# Altium schematic sheet ESP12_MinBreakOut.SchDoc
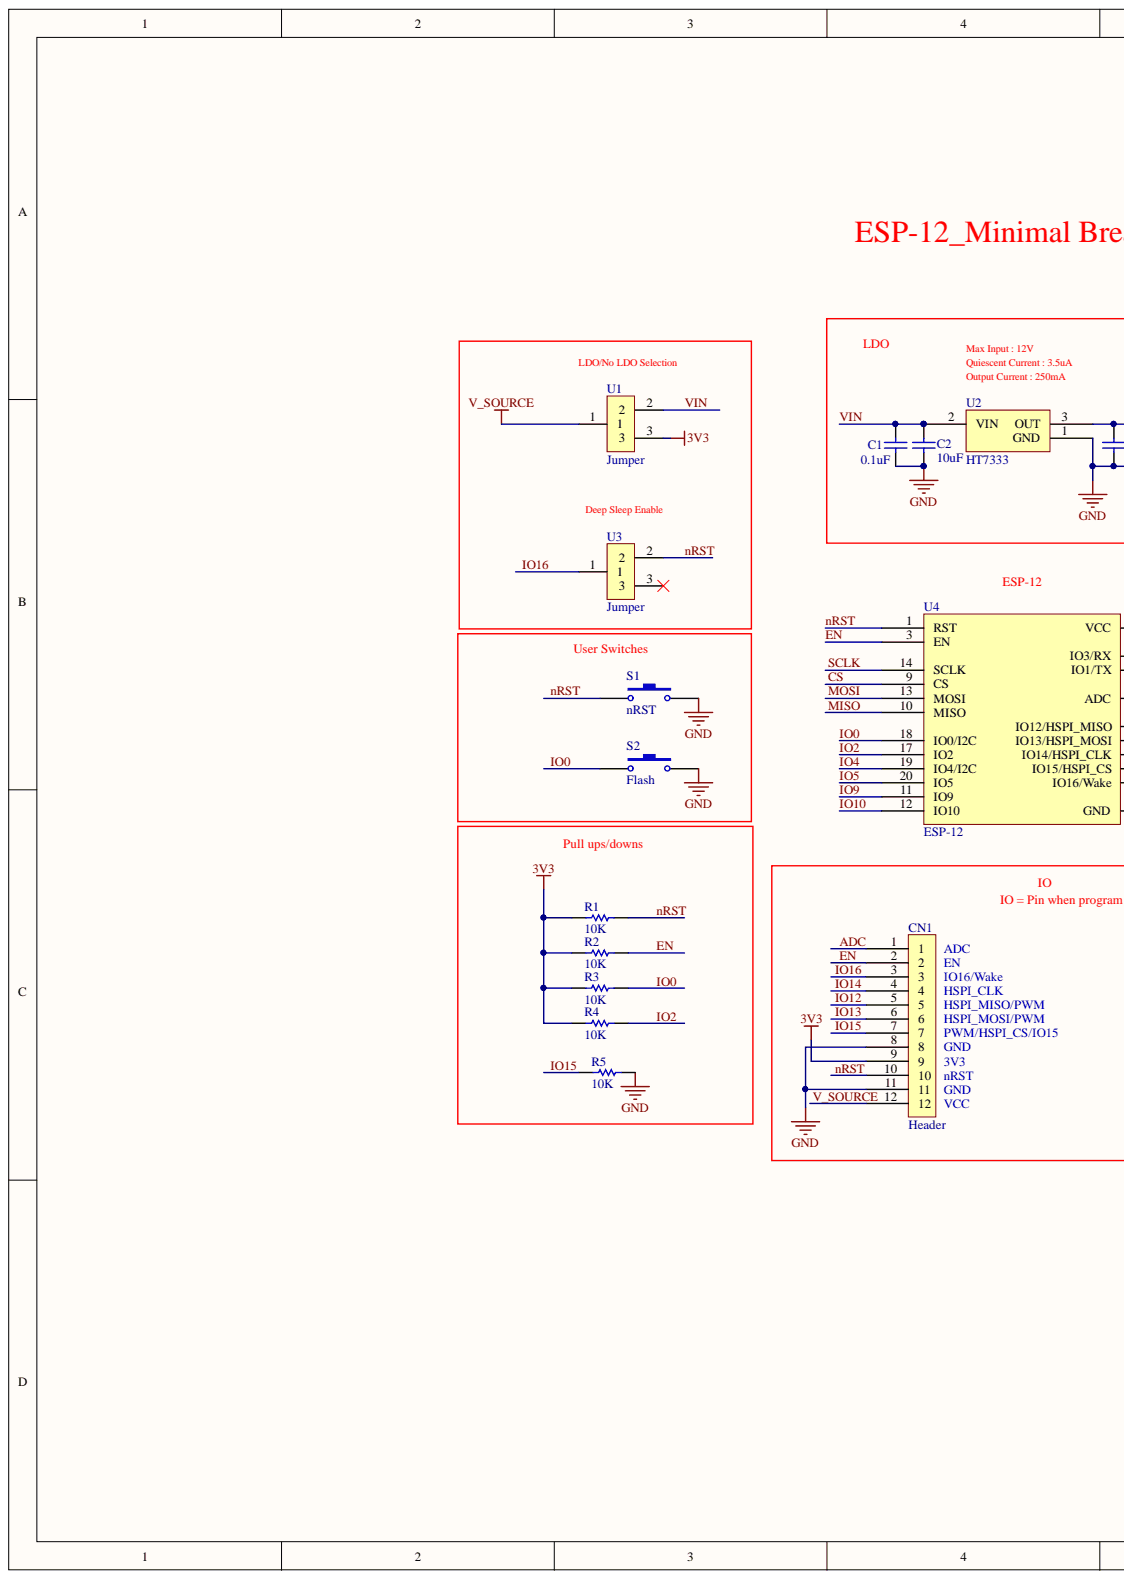
[diagram p0: ESP12_MinBreakOut.SchDoc, left]
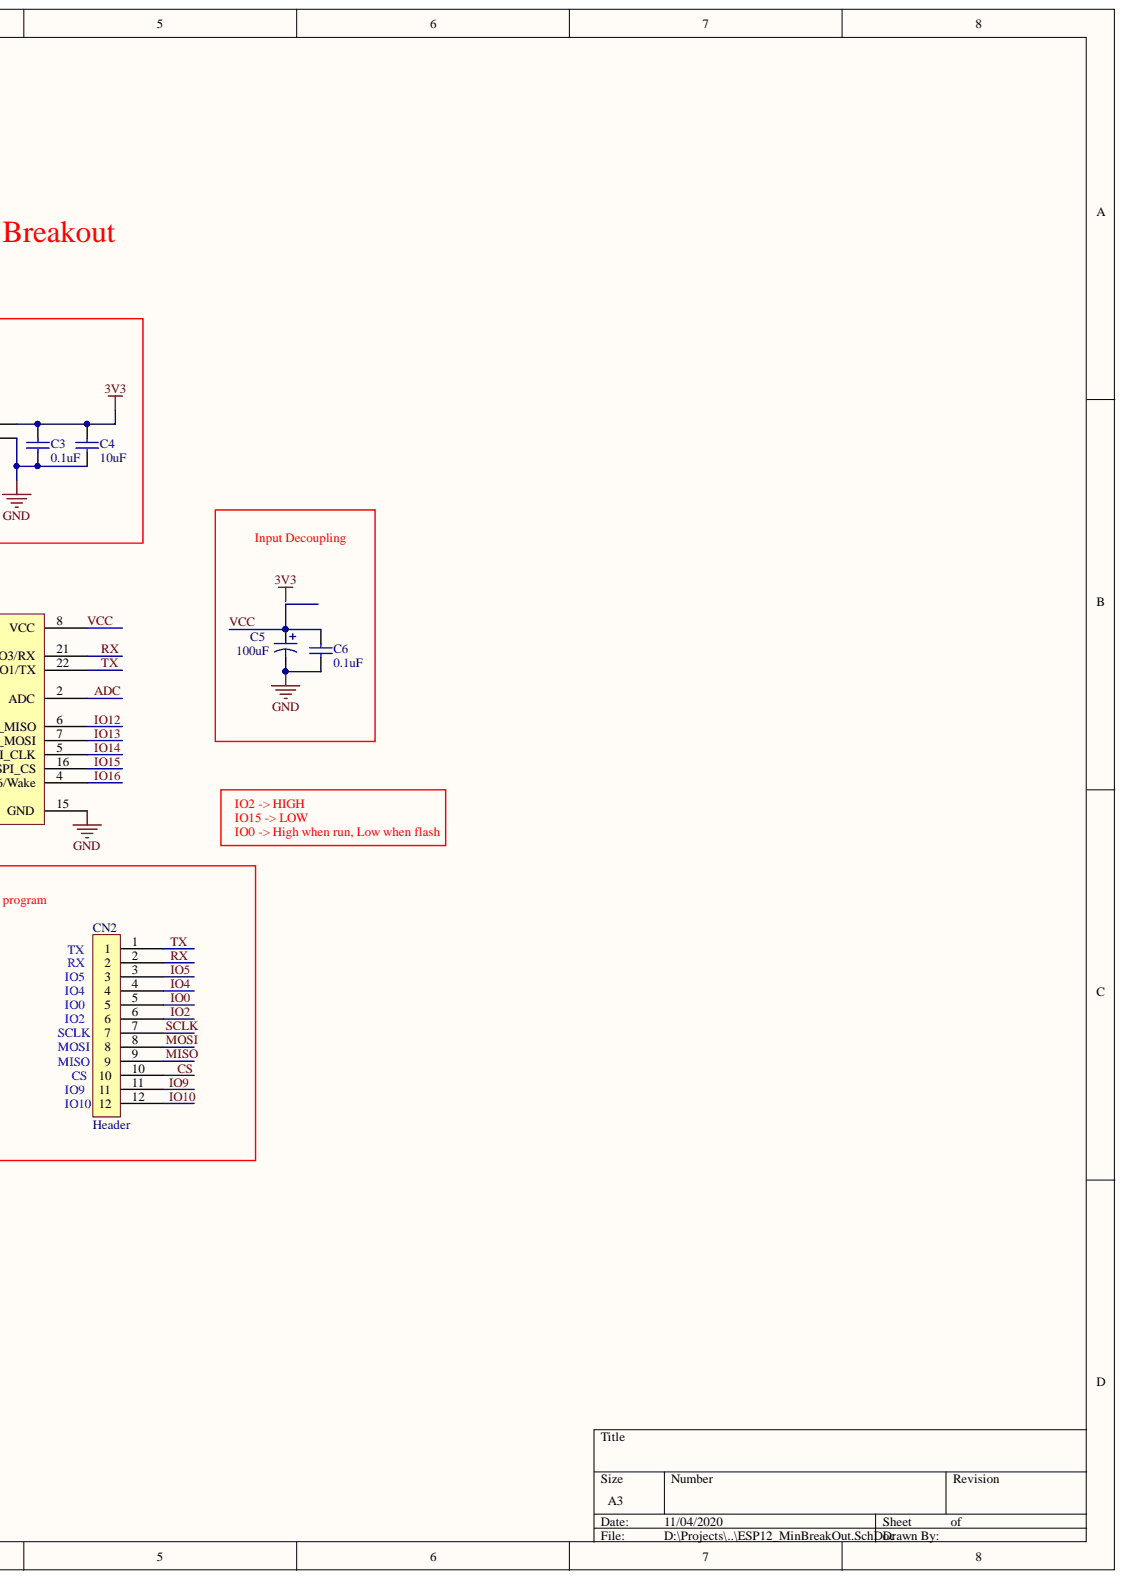
[diagram p1: ESP12_MinBreakOut.SchDoc, right]

## Parts
C1  0.1uF  [CAP_0805]
C2  10uF  [CAP_0805]
C3  0.1uF  [CAP_0805]
C4  10uF  [CAP_0805]
C5  100uF  [T490A107M006ATE800]
C6  0.1uF  [CAP_0805]
CN1  Header  [1x12 Header]
CN2  Header  [1x12 Header]
R1  10K  [RES_0805]
R2  10K  [RES_0805]
R3  10K  [RES_0805]
R4  10K  [RES_0805]
R5  10K  [RES_0805]
S1  nRST  [TS-1088R-02026]
S2  Flash  [TS-1088R-02026]
U1  Jumper  [Jumper]
U2  HT7333  [SOT-89-3]
U3  Jumper  [Jumper]
U4  ESP-12  [ESP12]

## Nets
3V3: C3.2 C4.2 CN1.9 R1.1 R2.1 R3.1 R4.1 U1.3 U2.3
GND: C1.1 C2.1 C3.1 C4.1 C5.2 C6.1 CN1.8 CN1.11 R5.2 S1.2 S2.2 U2.1 U4.15(GND)
ADC: CN1.1 U4.2(ADC)
CS: CN2.10 U4.9(CS)
EN: CN1.2 R2.2 U4.3(EN)
IO0: CN2.5 R3.2 S2.1 U4.18(IO0/I2C)
IO2: CN2.6 R4.2 U4.17(IO2)
IO4: CN2.4 U4.19(IO4/I2C)
IO5: CN2.3 U4.20(IO5)
IO9: CN2.11 U4.11(IO9)
IO10: CN2.12 U4.12(IO10)
IO12: CN1.5 U4.6(IO12/HSPI_MISO)
IO13: CN1.6 U4.7(IO13/HSPI_MOSI)
IO14: CN1.4 U4.5(IO14/HSPI_CLK)
IO15: CN1.7 R5.1 U4.16(IO15/HSPI_CS)
IO16: CN1.3 U3.1 U4.4(IO16/Wake)
MISO: CN2.9 U4.10(MISO)
MOSI: CN2.8 U4.13(MOSI)
nRST: CN1.10 R1.2 S1.1 U3.2 U4.1(RST)
RX: CN2.2 U4.21(IO3/RX)
SCLK: CN2.7 U4.14(SCLK)
TX: CN2.1 U4.22(IO1/TX)
V_SOURCE: CN1.12 U1.1
VCC: C5.1 C6.2 U4.8(VCC)
VIN: C1.2 C2.2 U1.2 U2.2

Not connected: U3 3

## Library data of the parts
C1 C3 C6 = Cap: 0805B104K250CT, 0805; Component Kind: Standard; LatestRevisionDate: 17-Jul-2002; LatestRevisionNote: Re-released for DXP Platform.; Library Name: Miscellaneous Devices.IntLib; PackageDescription: Radial Cap, Thru-Hole; 2 Leads; 0.3 in Pin Spacing; PackageReference: RAD-0.3; Published: 8-Jun-2000; Signal Integrity: Cap; Simulation: CAP; Publisher: Altium Limited
C2 C4 = Cap: GRM21BB31A106KE18L, 0805; Component Kind: Standard; LatestRevisionDate: 17-Jul-2002; LatestRevisionNote: Re-released for DXP Platform.; Library Name: Miscellaneous Devices.IntLib; PackageDescription: Radial Cap, Thru-Hole; 2 Leads; 0.3 in Pin Spacing; PackageReference: RAD-0.3; Published: 8-Jun-2000; Signal Integrity: Cap; Simulation: CAP; Publisher: Altium Limited
C5 = Cap Pol1: T490A107M006ATE800,100uF 6.3V CASE-A_3216 RoHS; Component Kind: Standard; LatestRevisionDate: 17-Jul-2002; LatestRevisionNote: Re-released for DXP Platform.; Library Name: Miscellaneous Devices.IntLib; PackageDescription: Polarized Capacitor (Radial); 2 Leads; PackageReference: RB7.6-15; Published: 8-Jun-2000; Signal Integrity: Cap Pol; Simulation: CAP; Publisher: Altium Limited
CN1 CN2 = Headers 1x12: 1x12 Male 2.54mm header; Component Kind: Standard; Library Name: ESP12_MinBreakOut.SchLib
R1-R5 = Res1: ERJ6GEYJ103V; Component Kind: Standard; LatestRevisionDate: 17-Jul-2002; LatestRevisionNote: Re-released for DXP Platform.; Library Name: Miscellaneous Devices.IntLib; PackageDescription: Axial Device, Thru-Hole; 2 Leads; 0.3 in Pin Spacing; PackageReference: AXIAL-0.3; Published: 8-Jun-2000; Signal Integrity: Res; Simulation: RESISTOR; Publisher: Altium Limited
S1 S2 = SW-PB: TS-1088R-02026; Component Kind: Standard; LatestRevisionDate: 17-Jul-2002; LatestRevisionNote: Re-released for DXP Platform.; Library Name: Miscellaneous Devices.IntLib; PackageDescription: Switch; 2 Leads; PackageReference: SPST-2; Published: 24-Mar-1999; Publisher: Altium Limited
U1 U3 = Jumper_Selection: Component Kind: Standard; Description: Jumper; Library Name: ESP12_MinBreakOut.SchLib
U2 = HT7333: Low Power Consumption LDO, 3.3V; Component Kind: Standard; Library Name: Lipo_Manager_V2.SchLib
U4 = ESP-12: Component Kind: Standard; Description: ESP-12; Library Name: ESP12_MinBreakOut.SchLib
